# Altium schematic sheet CW341_SRAM.SchDoc
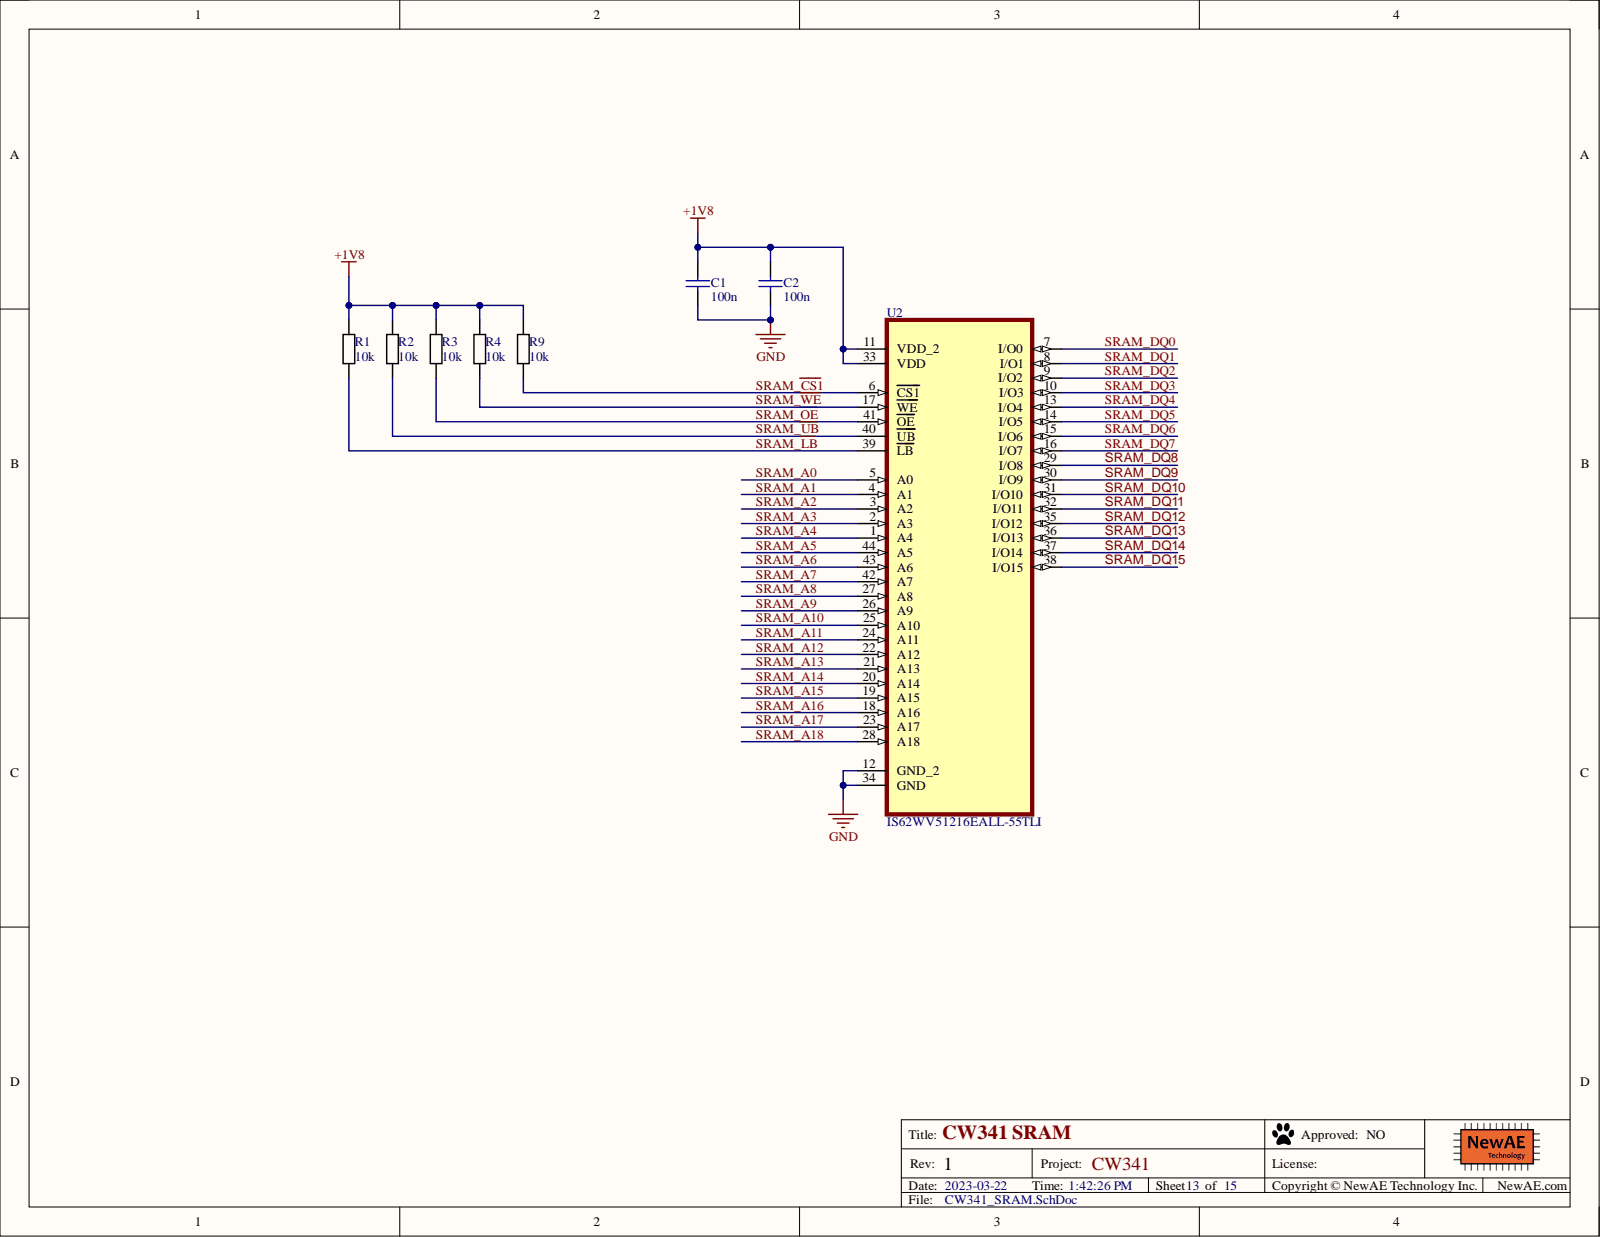
[diagram p0: CW341_SRAM.SchDoc]

## Parts
C1  100n  [0402]
C2  100n  [0402]
R1  10k  [0402]
R2  10k  [0402]
R3  10k  [0402]
R4  10k  [0402]
R9  10k  [0402]
U2  SRAM - 8Mb (1M x 8) Parallel  55ns 44-TSOP II  [TSSOP-44]  (value not drawn)

## Nets
+1V8: C1.1 C2.1 R1.1 R2.1 R3.1 R4.1 R9.1 U2.11(VDD_2) U2.33(VDD)
GND: C1.2 C2.2 U2.12(GND_2) U2.34(GND)
SRAM_A0: U2.5(A0)
SRAM_A1: U2.4(A1)
SRAM_A2: U2.3(A2)
SRAM_A3: U2.2(A3)
SRAM_A4: U2.1(A4)
SRAM_A5: U2.44(A5)
SRAM_A6: U2.43(A6)
SRAM_A7: U2.42(A7)
SRAM_A8: U2.27(A8)
SRAM_A9: U2.26(A9)
SRAM_A10: U2.25(A10)
SRAM_A11: U2.24(A11)
SRAM_A12: U2.22(A12)
SRAM_A13: U2.21(A13)
SRAM_A14: U2.20(A14)
SRAM_A15: U2.19(A15)
SRAM_A16: U2.18(A16)
SRAM_A17: U2.23(A17)
SRAM_A18: U2.28(A18)
SRAM_~{CS1}: R9.2 U2.6(CS1)
SRAM_DQ0: U2.7(I/O0)
SRAM_DQ1: U2.8(I/O1)
SRAM_DQ2: U2.9(I/O2)
SRAM_DQ3: U2.10(I/O3)
SRAM_DQ4: U2.13(I/O4)
SRAM_DQ5: U2.14(I/O5)
SRAM_DQ6: U2.15(I/O6)
SRAM_DQ7: U2.16(I/O7)
SRAM_DQ8: U2.29(I/O8)
SRAM_DQ9: U2.30(I/O9)
SRAM_DQ10: U2.31(I/O10)
SRAM_DQ11: U2.32(I/O11)
SRAM_DQ12: U2.35(I/O12)
SRAM_DQ13: U2.36(I/O13)
SRAM_DQ14: U2.37(I/O14)
SRAM_DQ15: U2.38(I/O15)
SRAM_~{LB}: R1.2 U2.39(LB)
SRAM_~{OE}: R3.2 U2.41(OE)
SRAM_~{UB}: R2.2 U2.40(UB)
SRAM_~{WE}: R4.2 U2.17(WE)

## Library data of the parts
C1 C2 = CCHIP-100n-0402: 100n, 0402; Manufacture Part Number 1: CGA2B3X7R1V104K050BB; Component Group: Capacitor; Database Table Name: main; Case-EIA: 0402; Component Kind: Standard; Component Type: Standard; Library Name: NewAE_AltiumLib.DBLib; Mounting Technology: SMT; Part Number: CCHIP-100n-0402; Pin Count: 2; Supplier 1: Digi-Key; Supplier Part Number 1: 445-6901-1-ND; Value: 100n
R1-R4 R9 = RCHIP-10K-0402: 10k res, 0402, 1%, 1/16W; Manufacture 1: Yageo; Manufacture Part Number 1: RC0402FR-0710KL; Case-Metric: 1005; Component Group: Resistor; Database Table Name: main; Rated Power: 0.063W; Rated Voltage: 75VDC; Case-EIA: 0402; Component Kind: Standard; Component Type: Standard; Library Name: NewAE_AltiumLib.DBLib; Mounting Technology: SMT; Part Number: RCHIP-10K-0402; Pin Count: 2; Supplier 1: Digi-Key; Supplier Part Number 1: 311-10.0KLRCT-ND; Temperature Range: -55C to 155C; Tolerance: 1%; Value: 10k
U2 = IS62WV51216EALL-55TLI: IC SRAM 8M PARALLEL 44TSOP II; Manufacture 1: Alliance Memory; Address Bus Width: 20b; Component Group: Digital IC; Max Supply Current: 60mA; Max Supply Voltage: 2.2V; Memory Type: RAM,SRAM - Asynchronous,SDR; Min Supply Voltage: 1.65V; Number of Ports: 1; Operating Supply Voltage: 3V; PP Material Stack: 0; Access Time: 55ns; Case/Package: TSOP; Category: RAM; Component Kind: Standard; Component Type: Standard; ComponentLink3Description: https://datasheet.ciiva.com/9274/as6c8008-9274921.pdf?src-supplier=Avnet; ComponentLink3URL: https://datasheet.ciiva.com/9274/as6c8008-9274921.pdf?src-supplier=Avnet; ComponentLink4Description: https://datasheet.ciiva.com/1510/32786-1510631.pdf?src-supplier=Element14; ComponentLink4URL: https://datasheet.ciiva.com/1510/32786-1510631.pdf?src-supplier=Element14; Density: 8Mb; Height: 1mm; Interface: Parallel; Lead Free: Lead Free; Length: 18.42mm; Manufacture Part Number 1: IS62WV51216EALL-55TLI; Manufacturer Part Number: IS62WV51216EALL-55TLI; Max Operating Temperature: 85°C; Memory Size: 8Mb; Min Operating Temperature: -40°C; Mount: Surface Mount; Mounting Technology: SMT; Number of Pins: 44; Package Quantity: 135; Packaging: Tray; Part Number: IS62WV51216EALL-55TLI; Pin Count: 44; PP Rotation: 0; Pricing 1: 1=6.76000, 10=6.21600, 25=6.08640, 50=6.06560, 135=5.44252, 270=5.27800, 540=5.01900, 1080=4.84400, 5130=4.35750 (USD); Radiation Hardening: No; REACH SVHC: No SVHC; RoHS: Yes; Stock 1: 1798; Supplier 1: Digi-Key; Supplier Part Number 1: 1450-1039-ND; Width: 10.16mm; Library Name: CW341_KintexUltraScaleTarget.SCHLIB
